# Altium schematic sheet 6_MUX.SchDoc
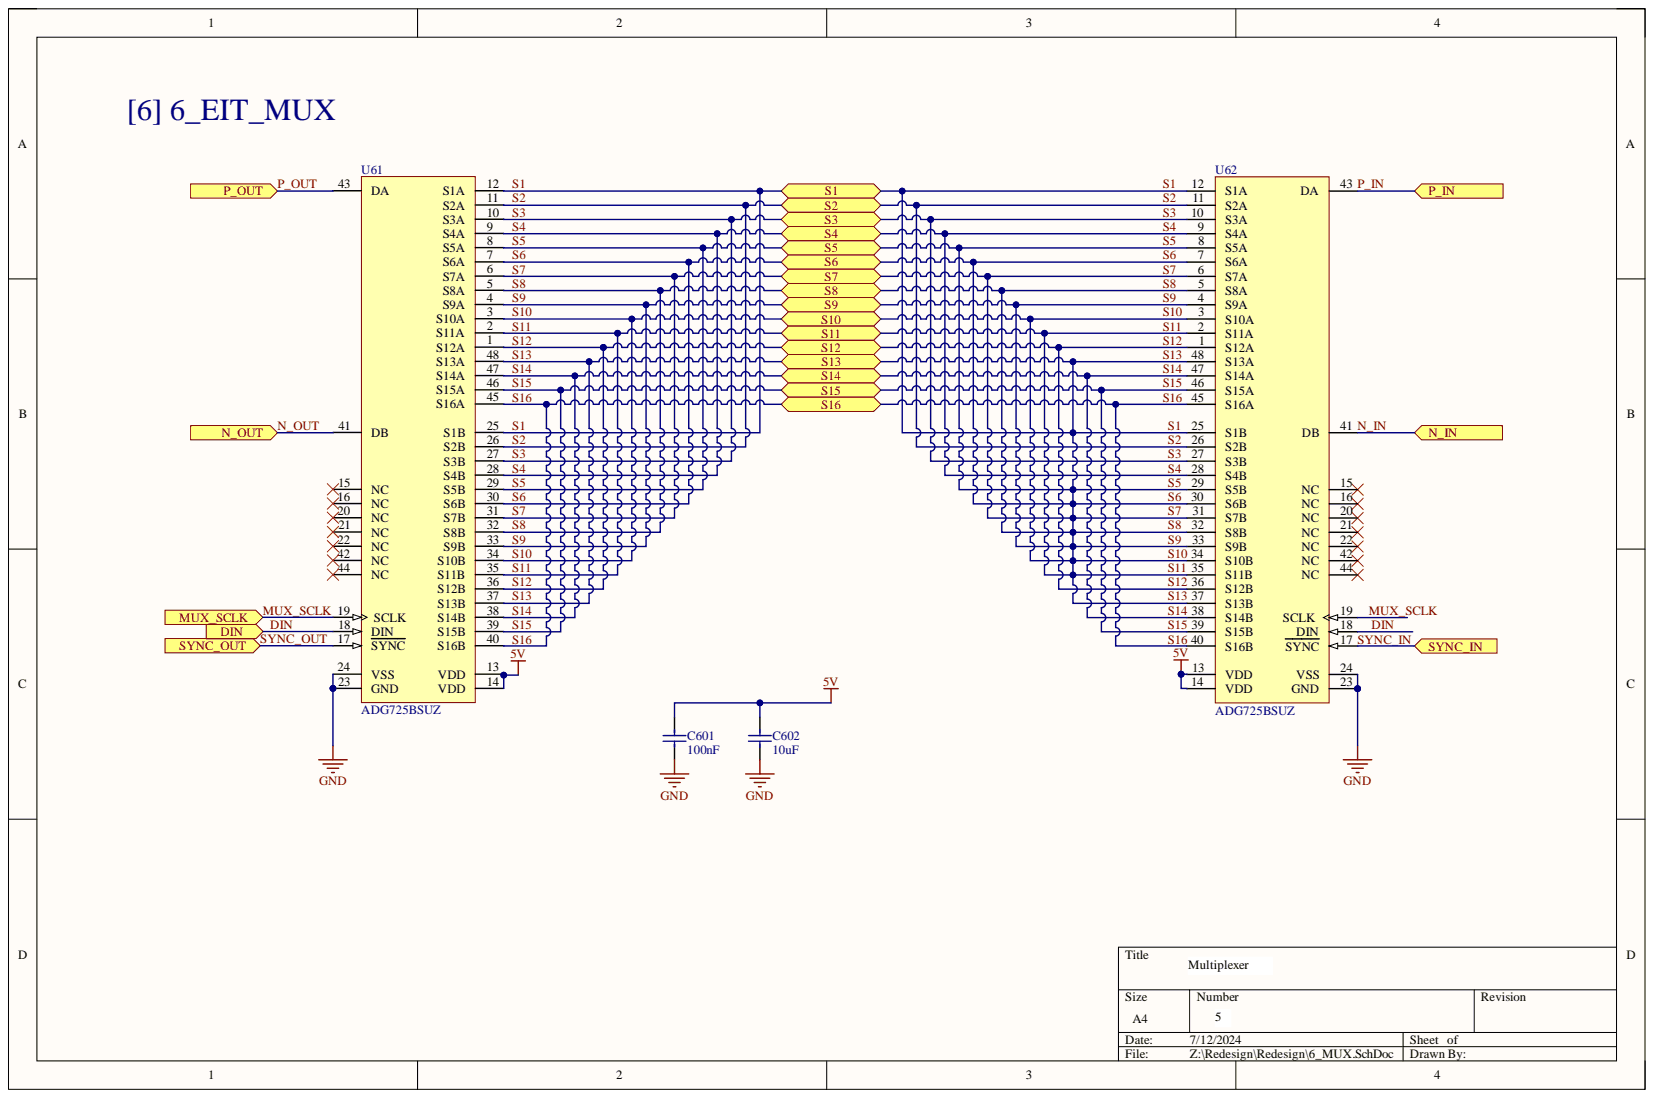
[diagram p0: 6_MUX.SchDoc]

## Parts
C601  value 100nF  [CAPC1005X55X30LL05T10]  (hidden comment: generic)
C602  value 10uF  [CAPC1005X55X30LL05T10]  (hidden comment: generic)
U61  ADG725BSUZ  [ADI-SU-48_N]
U62  ADG725BSUZ  [ADI-SU-48_N]

## Ports
DIN, MUX_SCLK, N_IN, N_OUT, P_IN, P_OUT, S1, S2, S3, S4, S5, S6, S7, S8, S9, S10, S11, S12, S13, S14, S15, S16, SYNC_IN, SYNC_OUT

## Nets
5V: C601.1 C602.2 U61.13(VDD) U61.14(VDD) U62.13(VDD) U62.14(VDD)
GND: C601.2 C602.1 U61.23(GND) U61.24(VSS) U62.23(GND) U62.24(VSS)
DIN: U61.18(DIN) U62.18(DIN) ; port DIN
MUX_SCLK: U61.19(SCLK) U62.19(SCLK) ; port MUX_SCLK
N_IN: U62.41(DB) ; port N_IN
N_OUT: U61.41(DB) ; port N_OUT
P_IN: U62.43(DA) ; port P_IN
P_OUT: U61.43(DA) ; port P_OUT
S1: U61.2(S11A) U61.3(S10A) U61.4(S9A) U61.5(S8A) U61.6(S7A) U61.7(S6A) U61.8(S5A) U61.12(S1A) U61.25(S1B) U61.29(S5B) U61.30(S6B) U61.31(S7B) U61.32(S8B) U61.33(S9B) U61.34(S10B) U61.35(S11B) U61.37(S13B) U61.48(S13A) U62.2(S11A) U62.3(S10A) U62.4(S9A) U62.5(S8A) U62.6(S7A) U62.7(S6A) U62.8(S5A) U62.12(S1A) U62.25(S1B) U62.29(S5B) U62.30(S6B) U62.31(S7B) U62.32(S8B) U62.33(S9B) U62.34(S10B) U62.35(S11B) U62.37(S13B) U62.48(S13A) ; port S1, port S10, port S11, port S13, port S5, port S6, port S7, port S8, port S9
S2: U61.11(S2A) U61.26(S2B) U62.11(S2A) U62.26(S2B) ; port S2
S3: U61.10(S3A) U61.27(S3B) U62.10(S3A) U62.27(S3B) ; port S3
S4: U61.9(S4A) U61.28(S4B) U62.9(S4A) U62.28(S4B) ; port S4
S12: U61.1(S12A) U61.36(S12B) U62.1(S12A) U62.36(S12B) ; port S12
S14: U61.38(S14B) U61.47(S14A) U62.38(S14B) U62.47(S14A) ; port S14
S15: U61.39(S15B) U61.46(S15A) U62.39(S15B) U62.46(S15A) ; port S15
S16: U61.40(S16B) U61.45(S16A) U62.40(S16B) U62.45(S16A) ; port S16
SYNC_IN: U62.17(SYNC) ; port SYNC_IN
SYNC_OUT: U61.17(SYNC) ; port SYNC_OUT

Not connected: U61 15(NC) 16(NC) 20(NC) 21(NC) 22(NC) 42(NC) 44(NC); U62 15(NC) 16(NC) 20(NC) 21(NC) 22(NC) 42(NC) 44(NC)

## Library data of the parts
C601 C602 = Capacitor: Component Kind: Standard; Description: generic; Device Class L1: Passive Components; Device Class L2: Capacitors; Library Name: RPI_EIT_SchLib.SchLib
U61 U62 = ADI-ADG725-SU-48: 1.8 to 5.5 V / +/-2.5 V, 4 Ohm, Serially Controlled, 16-Channel Analog Multiplexer, -40 to 85 degC, 48-pin QFP (SU-48), Tray; Manufacturer: Analog Devices; Bandwidth (Hz): 34M; Max Analog Signal Range: Vss to Vdd; Ron (ohms): 4; Supply V Guar Performance: Dual_(+/-2.5V), Single_(+3V), Single_(+5V); t transition (s): 42n; Code_JEDEC: MS-026ABC; Component Kind: Standard; ComponentLink1Description: Manufacturer URL; ComponentLink1URL: http://www.analog.com/en/index.html; ComponentLink2Description: Datasheet; ComponentLink2URL: http://www.analog.com/static/imported-files/data_sheets/ADG725_731.pdf; ComponentLink3Description: Package Specification; ComponentLink3URL: http://www.analog.com/static/imported-files/packages/470644706845302410119987SU48_7x7.pdf; DatasheetVersion: Rev. A; Mounting Technology: Surface Mount; PackageDescription: 48-Pin Thin Plastic Quad Flat Package, Body 7 x 7 mm, Pitch 0.5 mm; PackageReference: SU-48; Packing: Tray; PartNumber: ADG725BSUZ; Library Name: RPI_EIT_SchLib.SchLib
